# Altium schematic sheet FBR_Conn.SchDoc(FBR_Conn)
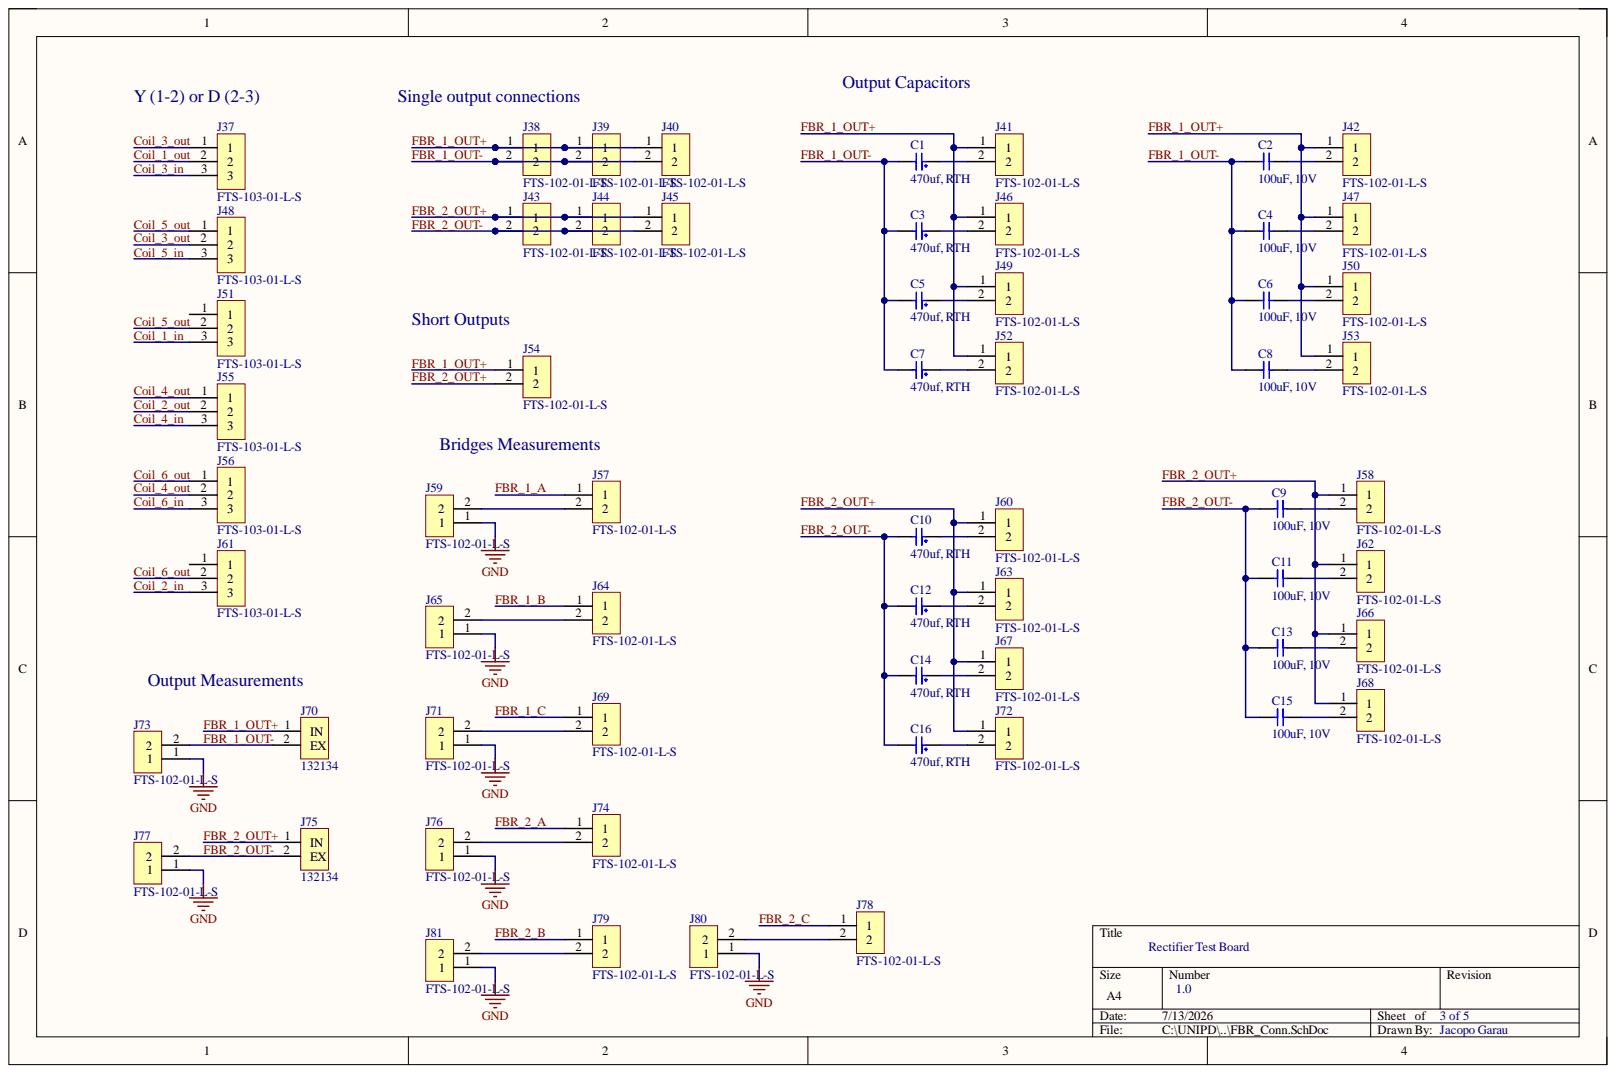
[diagram p0: FBR_Conn.SchDoc]

## Parts
C1  470uf, RTH  [CAP_YX_8X11P5_RUB]
C2  100uF, 10V  [CAP_1206]
C3  470uf, RTH  [CAP_YX_8X11P5_RUB]
C4  100uF, 10V  [CAP_1206]
C5  470uf, RTH  [CAP_YX_8X11P5_RUB]
C6  100uF, 10V  [CAP_1206]
C7  470uf, RTH  [CAP_YX_8X11P5_RUB]
C8  100uF, 10V  [CAP_1206]
C9  100uF, 10V  [CAP_1206]
C10  470uf, RTH  [CAP_YX_8X11P5_RUB]
C11  100uF, 10V  [CAP_1206]
C12  470uf, RTH  [CAP_YX_8X11P5_RUB]
C13  100uF, 10V  [CAP_1206]
C14  470uf, RTH  [CAP_YX_8X11P5_RUB]
C15  100uF, 10V  [CAP_1206]
C16  470uf, RTH  [CAP_YX_8X11P5_RUB]
J37  FTS-103-01-L-S  [HDR_1x3_1.27mm]
J38  FTS-102-01-L-S  [HDR_1x2_1.27mm]
J39  FTS-102-01-L-S  [HDR_1x2_1.27mm]
J40  FTS-102-01-L-S  [HDR_1x2_1.27mm]
J41  FTS-102-01-L-S  [HDR_1x2_1.27mm]
J42  FTS-102-01-L-S  [HDR_1x2_1.27mm]
J43  FTS-102-01-L-S  [HDR_1x2_1.27mm]
J44  FTS-102-01-L-S  [HDR_1x2_1.27mm]
J45  FTS-102-01-L-S  [HDR_1x2_1.27mm]
J46  FTS-102-01-L-S  [HDR_1x2_1.27mm]
J47  FTS-102-01-L-S  [HDR_1x2_1.27mm]
J48  FTS-103-01-L-S  [HDR_1x3_1.27mm]
J49  FTS-102-01-L-S  [HDR_1x2_1.27mm]
J50  FTS-102-01-L-S  [HDR_1x2_1.27mm]
J51  FTS-103-01-L-S  [HDR_1x3_1.27mm]
J52  FTS-102-01-L-S  [HDR_1x2_1.27mm]
J53  FTS-102-01-L-S  [HDR_1x2_1.27mm]
J54  FTS-102-01-L-S  [HDR_1x2_1.27mm]
J55  FTS-103-01-L-S  [HDR_1x3_1.27mm]
J56  FTS-103-01-L-S  [HDR_1x3_1.27mm]
J57  FTS-102-01-L-S  [HDR_1x2_1.27mm]
J58  FTS-102-01-L-S  [HDR_1x2_1.27mm]
J59  FTS-102-01-L-S  [HDR_1x2_1.27mm]
J60  FTS-102-01-L-S  [HDR_1x2_1.27mm]
J61  FTS-103-01-L-S  [HDR_1x3_1.27mm]
J62  FTS-102-01-L-S  [HDR_1x2_1.27mm]
J63  FTS-102-01-L-S  [HDR_1x2_1.27mm]
J64  FTS-102-01-L-S  [HDR_1x2_1.27mm]
J65  FTS-102-01-L-S  [HDR_1x2_1.27mm]
J66  FTS-102-01-L-S  [HDR_1x2_1.27mm]
J67  FTS-102-01-L-S  [HDR_1x2_1.27mm]
J68  FTS-102-01-L-S  [HDR_1x2_1.27mm]
J69  FTS-102-01-L-S  [HDR_1x2_1.27mm]
J70  132134  [AMPHENOL_132134]
J71  FTS-102-01-L-S  [HDR_1x2_1.27mm]
J72  FTS-102-01-L-S  [HDR_1x2_1.27mm]
J73  FTS-102-01-L-S  [HDR_1x2_1.27mm]
J74  FTS-102-01-L-S  [HDR_1x2_1.27mm]
J75  132134  [AMPHENOL_132134]
J76  FTS-102-01-L-S  [HDR_1x2_1.27mm]
J77  FTS-102-01-L-S  [HDR_1x2_1.27mm]
J78  FTS-102-01-L-S  [HDR_1x2_1.27mm]
J79  FTS-102-01-L-S  [HDR_1x2_1.27mm]
J80  FTS-102-01-L-S  [HDR_1x2_1.27mm]
J81  FTS-102-01-L-S  [HDR_1x2_1.27mm]

## Nets
GND: J59.1 J65.1 J71.1 J73.1 J76.1 J77.1 J80.1 J81.1
Coil_1_in: J51.3
Coil_1_out: J37.2
Coil_2_in: J61.3
Coil_2_out: J55.2
Coil_3_in: J37.3
Coil_3_out: J37.1 J48.2
Coil_4_in: J55.3
Coil_4_out: J55.1 J56.2
Coil_5_in: J48.3
Coil_5_out: J48.1 J51.2
Coil_6_in: J56.3
Coil_6_out: J56.1 J61.2
FBR_1_A: J57.1
FBR_1_B: J64.1
FBR_1_C: J69.1
FBR_1_OUT+: J38.1 J39.1 J40.1 J41.1 J42.1 J46.1 J47.1 J49.1 J50.1 J52.1 J53.1 J54.1 J70.1
FBR_1_OUT-: C1.2 C2.1 C3.2 C4.1 C5.2 C6.1 C7.2 C8.1 J38.2 J39.2 J40.2 J70.2 J73.2
FBR_2_A: J74.1
FBR_2_B: J79.1
FBR_2_C: J78.1
FBR_2_OUT+: J43.1 J44.1 J45.1 J54.2 J58.1 J60.1 J62.1 J63.1 J66.1 J67.1 J68.1 J72.1 J75.1
FBR_2_OUT-: C9.1 C10.2 C11.1 C12.2 C13.1 C14.2 C15.1 C16.2 J43.2 J44.2 J45.2 J75.2 J77.2
NetC1_1: C1.1 J41.2
NetC2_2: C2.2 J42.2
NetC3_1: C3.1 J46.2
NetC4_2: C4.2 J47.2
NetC5_1: C5.1 J49.2
NetC6_2: C6.2 J50.2
NetC7_1: C7.1 J52.2
NetC8_2: C8.2 J53.2
NetC9_2: C9.2 J58.2
NetC10_1: C10.1 J60.2
NetC11_2: C11.2 J62.2
NetC12_1: C12.1 J63.2
NetC13_2: C13.2 J66.2
NetC14_1: C14.1 J67.2
NetC15_2: C15.2 J68.2
NetC16_1: C16.1 J72.2
NetJ57_2: J57.2 J59.2
NetJ64_2: J64.2 J65.2
NetJ69_2: J69.2 J71.2
NetJ74_2: J74.2 J76.2
NetJ78_2: J78.2 J80.2
NetJ79_2: J79.2 J81.2

Not connected: J51 1; J61 1

## Library data of the parts
C1 C3 C5 C7 C10 C12 C14 C16 = 16ZLH470MEFCT78X11.5: Aluminum Electrolytic Capacitor, Polarized, Aluminum (wet), 16V, 20% +Tol, 20% -Tol, 470uF, Through Hole Mount; Manufacturer: Rubycon; Impedance: 56mΩ; Leakage Current: 75.2µA; Life (Hours): 8000hours; Ripple Current: 519.75mA; Value: 470µF; Case/Package: Radial; Category: Aluminum Electrolytic Capacitors; Component Kind: Standard; ComponentLink1Description: https://datasheet.octopart.com:443/16ZLH470MEFCT78X11.5-Rubycon-datasheet-154841597.pdf?src-supplier=IHS; ComponentLink1URL: https://datasheet.octopart.com:443/16ZLH470MEFCT78X11.5-Rubycon-datasheet-154841597.pdf?src-supplier=IHS; ComponentLink2Description: https://datasheet.octopart.com:443/16ZLH470MEFCT78X11.5-Rubycon-datasheet-86451566.pdf?src-supplier=Electro+Sonic; ComponentLink2URL: https://datasheet.octopart.com:443/16ZLH470MEFCT78X11.5-Rubycon-datasheet-86451566.pdf?src-supplier=Electro+Sonic; Country of Origin: Japan; Diameter: 8mm; Export Control Classification Number (ECCN) Code: EAR99; Harmonized Tariff Schedule (HTS) Code: 8532.22.00.20; Height - Seated (Max): 13.0048mm; Introduction Date: 2010-09-20; Lead Pitch: 3.5052mm; Length: 11.5mm; Library Name: MySchLib.SchLib; Lifecycle Status: Production; Manufacturer Part Number: 16ZLH470MEFCT78X11.5; Max Operating Temperature: 105°C; Min Operating Temperature: -40°C; Mounting Technology: Through Hole; Number of Terminals: 2; Packaging: Tape & Box (TB); Pricing: 1=0.45, 10=0.28, 50=0.2056, 100=0.1821, 500=0.14146 (USD); REACH SVHC: Yes; RoHS: Compliant; Stock: 21478; Supplier: DigiKey; Supplier Part Number: 1189-3712-1-ND; Terminal Pitch: 3.5mm; Tolerance: 20%; Voltage Rating: 16V; Voltage Rating (DC): 16V
C2 C4 C6 C8 C9 C11 C13 C15 = GRM31CR61A107MEA8L: 10V 100uF X5R ±20% 1206 Multilayer Ceramic Capacitors MLCC - SMD/SMT ROHS; Manufacturer: Murata; Manufacturer Lifecycle Status: IN PRODUCTION; Value: 100µF; Case/Package: 1206; Category: Ceramic Capacitors; Component Kind: Standard; ComponentLink1Description: https://datasheet.octopart.com:443/GRM31CR61A107MEA8L-Murata-datasheet-165579666.pdf?src-supplier=TME; ComponentLink1URL: https://datasheet.octopart.com:443/GRM31CR61A107MEA8L-Murata-datasheet-165579666.pdf?src-supplier=TME; ComponentLink2Description: https://datasheet.octopart.com/GRM31CR61A107MEA8L-Murata-datasheet-12515295.pdf; ComponentLink2URL: https://datasheet.octopart.com/GRM31CR61A107MEA8L-Murata-datasheet-12515295.pdf; ComponentLink3Description: https://datasheet.octopart.com/GRM31CR61A107MEA8L-Murata-datasheet-12510076.pdf; ComponentLink3URL: https://datasheet.octopart.com/GRM31CR61A107MEA8L-Murata-datasheet-12510076.pdf; ComponentLink4Description: https://datasheet.octopart.com/GRM31CR61A107MEA8L-Murata-datasheet-11978611.pdf; ComponentLink4URL: https://datasheet.octopart.com/GRM31CR61A107MEA8L-Murata-datasheet-11978611.pdf; ComponentLink5Description: https://datasheet.octopart.com/GRM31CR61A107MEA8L-Murata-datasheet-10025737.pdf; ComponentLink5URL: https://datasheet.octopart.com/GRM31CR61A107MEA8L-Murata-datasheet-10025737.pdf; ComponentLink6Description: https://datasheet.octopart.com/GRM31CR61A107MEA8L-Murata-datasheet-8819587.pdf; ComponentLink6URL: https://datasheet.octopart.com/GRM31CR61A107MEA8L-Murata-datasheet-8819587.pdf; ComponentLink7Description: http://sigma.octopart.com:80/reach_statement/Murata-company-16.pdf?src-supplier=Compliance+Statements; ComponentLink7URL: http://sigma.octopart.com:80/reach_statement/Murata-company-16.pdf?src-supplier=Compliance+Statements; Dielectric: X5R; Dielectric Material: Ceramic; Export Control Classification Number (ECCN) Code: EAR99; Harmonized Tariff Schedule (HTS) Code: 8532.24.00.20; Height: 1.6mm; Introduction Date: 2020-12-28; Length: 3.2mm; Library Name: My_Integrated_Library.IntLib; Lifecycle Status: Production; Manufacturer Part Number: GRM31CR61A107MEA8L; Material: Ceramic; Max Operating Temperature: 85°C; Min Operating Temperature: -55°C; Mounting Technology: Surface Mount; Number of Terminals: 2; Pricing: 1=0.49, 10=0.3, 50=0.2244, 100=0.2009, 500=0.16148, 1000=0.14931 (USD); REACH SVHC: Yes; RoHS: Compliant; Stock: 193494; Supplier: DigiKey; Supplier Part Number: 490-GRM31CR61A107MEA8LDKR-ND; Thickness: 1.6mm; Tolerance: 20%; Voltage Rating: 10V; Voltage Rating (DC): 10V; Width: 1.6mm
J37 J48 J51 J55 J56 J61 = FTS-103-01-L-S: CONN HEADER VERT 3POS 1.27MM; Manufacturer: Samtec; Manufacturer Lifecycle Status: PRODUCTION; Gender: Male; Number of Contacts: 3; Category: Board to Board Connectors; Component Kind: Standard; ComponentLink1Description: https://datasheet.octopart.com:443/FTS-103-01-L-S-Samtec-datasheet-97029283.pdf?src-supplier=Newark; ComponentLink1URL: https://datasheet.octopart.com:443/FTS-103-01-L-S-Samtec-datasheet-97029283.pdf?src-supplier=Newark; ComponentLink2Description: https://sigma.octopart.com:443/97029285/technical_drawing/Samtec-FTS-103-01-L-S.pdf?src-supplier=Newark; ComponentLink2URL: https://sigma.octopart.com:443/97029285/technical_drawing/Samtec-FTS-103-01-L-S.pdf?src-supplier=Newark; ComponentLink3Description: https://datasheet.octopart.com:443/FTS-103-01-L-S-Samtec-datasheet-118493358.pdf?src-supplier=Electro+Sonic; ComponentLink3URL: https://datasheet.octopart.com:443/FTS-103-01-L-S-Samtec-datasheet-118493358.pdf?src-supplier=Electro+Sonic; ComponentLink4Description: https://datasheet.octopart.com:443/FTS-103-01-L-S-Samtec-datasheet-165596534.pdf?src-supplier=JRH+Electronics; ComponentLink4URL: https://datasheet.octopart.com:443/FTS-103-01-L-S-Samtec-datasheet-165596534.pdf?src-supplier=JRH+Electronics; ComponentLink5Description: https://datasheet.octopart.com:443/FTS-103-01-L-S-Samtec-datasheet-176663378.pdf?src-supplier=Toby+Electronics; ComponentLink5URL: https://datasheet.octopart.com:443/FTS-103-01-L-S-Samtec-datasheet-176663378.pdf?src-supplier=Toby+Electronics; ComponentLink6Description: https://datasheet.octopart.com:443/FTS-103-01-L-S-Samtec-datasheet-116535195.pdf?src-supplier=Toby+Electronics; ComponentLink6URL: https://datasheet.octopart.com:443/FTS-103-01-L-S-Samtec-datasheet-116535195.pdf?src-supplier=Toby+Electronics; ComponentLink7Description: https://datasheet.octopart.com:443/FTS-103-01-L-S-Samtec-datasheet-136395376.pdf?src-supplier=Toby+Electronics; ComponentLink7URL: https://datasheet.octopart.com:443/FTS-103-01-L-S-Samtec-datasheet-136395376.pdf?src-supplier=Toby+Electronics; ComponentLink8Description: https://datasheet.octopart.com:443/FTS-103-01-L-S-Samtec-datasheet-151470456.pdf?src-supplier=Sager+Electronics; ComponentLink8URL: https://datasheet.octopart.com:443/FTS-103-01-L-S-Samtec-datasheet-151470456.pdf?src-supplier=Sager+Electronics; Contact Plating: Gold; Library Name: Schlib.SchLib; Lifecycle Status: Production; Manufacturer Part Number: FTS-103-01-L-S; Mounting Technology: Through Hole; Number of Rows: 1; Packaging: Bulk; Pitch: 1.27mm; Pricing: 1=0.85, 50=0.744, 100=0.631, 500=0.524, 1000=0.448, 2000=0.36601, 5000=0.34448 (USD); Radiation Hardening: No; RoHS: Compliant; Stock: 1520; Supplier: DigiKey; Supplier Part Number: SAM15177-ND; Termination: Solder
J38-J47 J49 J50 J52-J54 J57-J60 J62-J69 J71-J74 J76-J81 = FTS-102-01-L-S: CONN HEADER VERT 2POS 1.27MM; Manufacturer: Samtec; Manufacturer Lifecycle Status: PRODUCTION; Gender: Male; Number of Contacts: 2; Category: Headers and Wire Housings; Component Kind: Standard; ComponentLink1Description: https://sigma.octopart.com:443/165596534/technical_drawing/Samtec-FTS-102-01-L-S.pdf?src-supplier=JRH+Electronics; ComponentLink1URL: https://sigma.octopart.com:443/165596534/technical_drawing/Samtec-FTS-102-01-L-S.pdf?src-supplier=JRH+Electronics; ComponentLink2Description: https://datasheet.octopart.com:443/FTS-102-01-L-S-Samtec-datasheet-164323441.pdf?src-supplier=Upverter; ComponentLink2URL: https://datasheet.octopart.com:443/FTS-102-01-L-S-Samtec-datasheet-164323441.pdf?src-supplier=Upverter; ComponentLink3Description: https://sigma.octopart.com:443/97029285/technical_drawing/Samtec-FTS-102-01-L-S.pdf?src-supplier=Newark; ComponentLink3URL: https://sigma.octopart.com:443/97029285/technical_drawing/Samtec-FTS-102-01-L-S.pdf?src-supplier=Newark; ComponentLink4Description: https://datasheet.octopart.com:443/FTS-102-01-L-S-Samtec-datasheet-97029283.pdf?src-supplier=Samtec; ComponentLink4URL: https://datasheet.octopart.com:443/FTS-102-01-L-S-Samtec-datasheet-97029283.pdf?src-supplier=Samtec; ComponentLink5Description: https://datasheet.octopart.com:443/FTS-102-01-L-S-Samtec-datasheet-118493358.pdf?src-supplier=Electro+Sonic; ComponentLink5URL: https://datasheet.octopart.com:443/FTS-102-01-L-S-Samtec-datasheet-118493358.pdf?src-supplier=Electro+Sonic; ComponentLink6Description: https://datasheet.octopart.com:443/FTS-102-01-L-S-Samtec-datasheet-176663378.pdf?src-supplier=Toby+Electronics; ComponentLink6URL: https://datasheet.octopart.com:443/FTS-102-01-L-S-Samtec-datasheet-176663378.pdf?src-supplier=Toby+Electronics; ComponentLink7Description: https://datasheet.octopart.com:443/FTS-102-01-L-S-Samtec-datasheet-116535195.pdf?src-supplier=Toby+Electronics; ComponentLink7URL: https://datasheet.octopart.com:443/FTS-102-01-L-S-Samtec-datasheet-116535195.pdf?src-supplier=Toby+Electronics; ComponentLink8Description: https://datasheet.octopart.com:443/FTS-102-01-L-S-Samtec-datasheet-136395376.pdf?src-supplier=Toby+Electronics; ComponentLink8URL: https://datasheet.octopart.com:443/FTS-102-01-L-S-Samtec-datasheet-136395376.pdf?src-supplier=Toby+Electronics; ComponentLink9Description: https://datasheet.octopart.com:443/FTS-102-01-L-S-Samtec-datasheet-151470456.pdf?src-supplier=Sager+Electronics; ComponentLink9URL: https://datasheet.octopart.com:443/FTS-102-01-L-S-Samtec-datasheet-151470456.pdf?src-supplier=Sager+Electronics; Contact Material: Gold, Nickel; Contact Plating: Gold; Height: 4.17mm; Housing Color: Black; Housing Material: Polymer; Length: 2.54mm; Library Name: Schlib.SchLib; Lifecycle Status: Production; Manufacturer Part Number: FTS-102-01-L-S; Max Operating Temperature: 125°C; Min Operating Temperature: -55°C; Number of Rows: 2; Orientation: Straight; Packaging: Bulk; Pitch: 1.27mm; Pricing: 1=0.57, 50=0.496, 100=0.42, 500=0.35, 1000=0.299, 2000=0.244, 5000=0.24223 (USD); Radiation Hardening: No; RoHS: Compliant; Stock: 1509; Supplier: DigiKey; Supplier Part Number: FTS-102-01-L-S-ND; Termination: Solder
J70 J75 = 132134: connector, rf coaxial, sma str jack for p.c.board, 4 legs, .050 center cont, 50 ohm; Manufacturer: Amphenol RF; Body Material: Brass; Body Plating: Gold; Clock Frequency: 18GHz; Connector Type: Coaxial, SMA, Socket; Dielectric Material: PTFE; Impedance: 50Ω; Number of Contacts: 1; Case/Package: SMA; Category: RF / Coaxial Connectors; Component Kind: Standard; ComponentLink1Description: https://datasheet.octopart.com:443/132134-Amphenol-RF-datasheet-178922999.pdf?src-supplier=ODG+(Origin+Data+Global); ComponentLink1URL: https://datasheet.octopart.com:443/132134-Amphenol-RF-datasheet-178922999.pdf?src-supplier=ODG+(Origin+Data+Global); ComponentLink2Description: https://datasheet.octopart.com:443/132134-Amphenol-RF-datasheet-11478678.pdf?src-supplier=TME; ComponentLink2URL: https://datasheet.octopart.com:443/132134-Amphenol-RF-datasheet-11478678.pdf?src-supplier=TME; ComponentLink3Description: https://datasheet.octopart.com:443/132134-Amphenol-RF-datasheet-21190506.pdf?src-supplier=element14+APAC; ComponentLink3URL: https://datasheet.octopart.com:443/132134-Amphenol-RF-datasheet-21190506.pdf?src-supplier=element14+APAC; ComponentLink4Description: https://datasheet.octopart.com:443/132134-Amphenol-datasheet-8508134.pdf?src-supplier=element14+APAC; ComponentLink4URL: https://datasheet.octopart.com:443/132134-Amphenol-datasheet-8508134.pdf?src-supplier=element14+APAC; ComponentLink5Description: https://datasheet.octopart.com:443/132134-Amphenol-RF-datasheet-48840.pdf?src-supplier=RS+(Formerly+Allied+Electronics); ComponentLink5URL: https://datasheet.octopart.com:443/132134-Amphenol-RF-datasheet-48840.pdf?src-supplier=RS+(Formerly+Allied+Electronics); ComponentLink6Description: https://datasheet.octopart.com:443/132134-Amphenol-datasheet-16831.pdf?src-supplier=DigiKey; ComponentLink6URL: https://datasheet.octopart.com:443/132134-Amphenol-datasheet-16831.pdf?src-supplier=DigiKey; ComponentLink7Description: https://datasheet.octopart.com:443/132134-Amphenol-Connex-datasheet-31974814.pdf?src-supplier=East+Coast+Microwave; ComponentLink7URL: https://datasheet.octopart.com:443/132134-Amphenol-Connex-datasheet-31974814.pdf?src-supplier=East+Coast+Microwave; ComponentLink8Description: https://datasheet.octopart.com:443/132134-Amphenol-Connex-datasheet-32030771.pdf?src-supplier=East+Coast+Microwave; ComponentLink8URL: https://datasheet.octopart.com:443/132134-Amphenol-Connex-datasheet-32030771.pdf?src-supplier=East+Coast+Microwave; ComponentLink9Description: https://datasheet.octopart.com:443/132134-Amphenol-RF-datasheet-177010530.pdf?src-supplier=Burklin+Elektronik; ComponentLink9URL: https://datasheet.octopart.com:443/132134-Amphenol-RF-datasheet-177010530.pdf?src-supplier=Burklin+Elektronik; ComponentLink10Description: https://datasheet.octopart.com:443/132134-Amphenol-datasheet-7088102.pdf?src-supplier=Jameco; ComponentLink10URL: https://datasheet.octopart.com:443/132134-Amphenol-datasheet-7088102.pdf?src-supplier=Jameco; Contact Gender: Female; Contact Material: Gold; Contact Plating: Gold; Country of Origin: Vietnam; Depth: 7mm; Export Control Classification Number (ECCN) Code: EAR99; Fastening Type: Threaded; Frequency: 18GHz; Harmonized Tariff Schedule (HTS) Code: 8536.69.40.10; Height: 9.73mm; Housing Color: Gold; Insulation Material: Teflon; Introduction Date: 2001-11-27; Lead Free: Lead Free; Length: 7mm; Library Name: Schlib.SchLib; Lifecycle Status: Production; Manufacturer Part Number: 132134; Max Operating Temperature: 165°C; Min Operating Temperature: -65°C; Mounting Technology: PCB, Through Hole; Number of Ports: 1; Orientation: Straight; Packaging: Bulk; Pins: 1; Pricing: 1=6.97, 10=5.922, 25=5.5508, 50=5.2856, 100=5.0333, 300=4.65793, 500=4.49316, 1000=4.27889 (USD); Radiation Hardening: No; REACH SVHC: No; RoHS: Compliant; Shell Material: Brass; Stock: 22451; Supplier: DigiKey; Supplier Part Number: ACX1230-ND; Termination: Solder; Weight: 1.000001g
